# Altium schematic sheet BLOCK WIRELESS.SchDoc
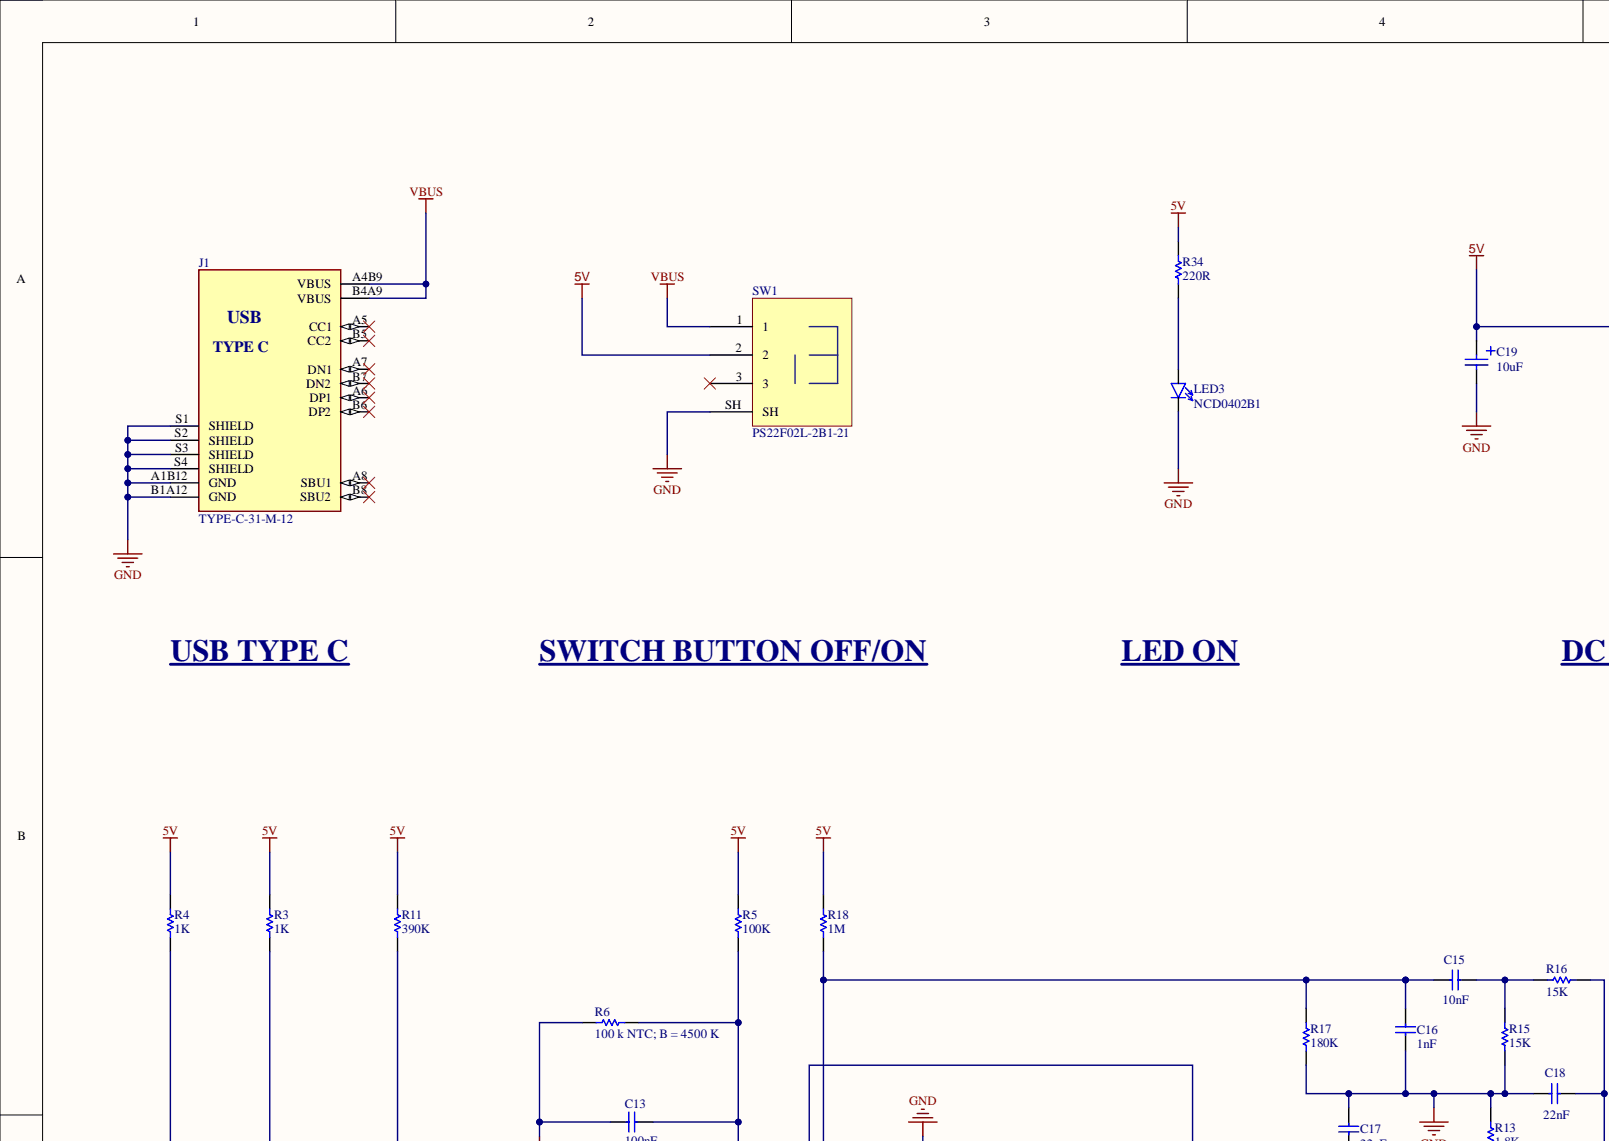
[diagram p0: BLOCK WIRELESS.SchDoc, top left]
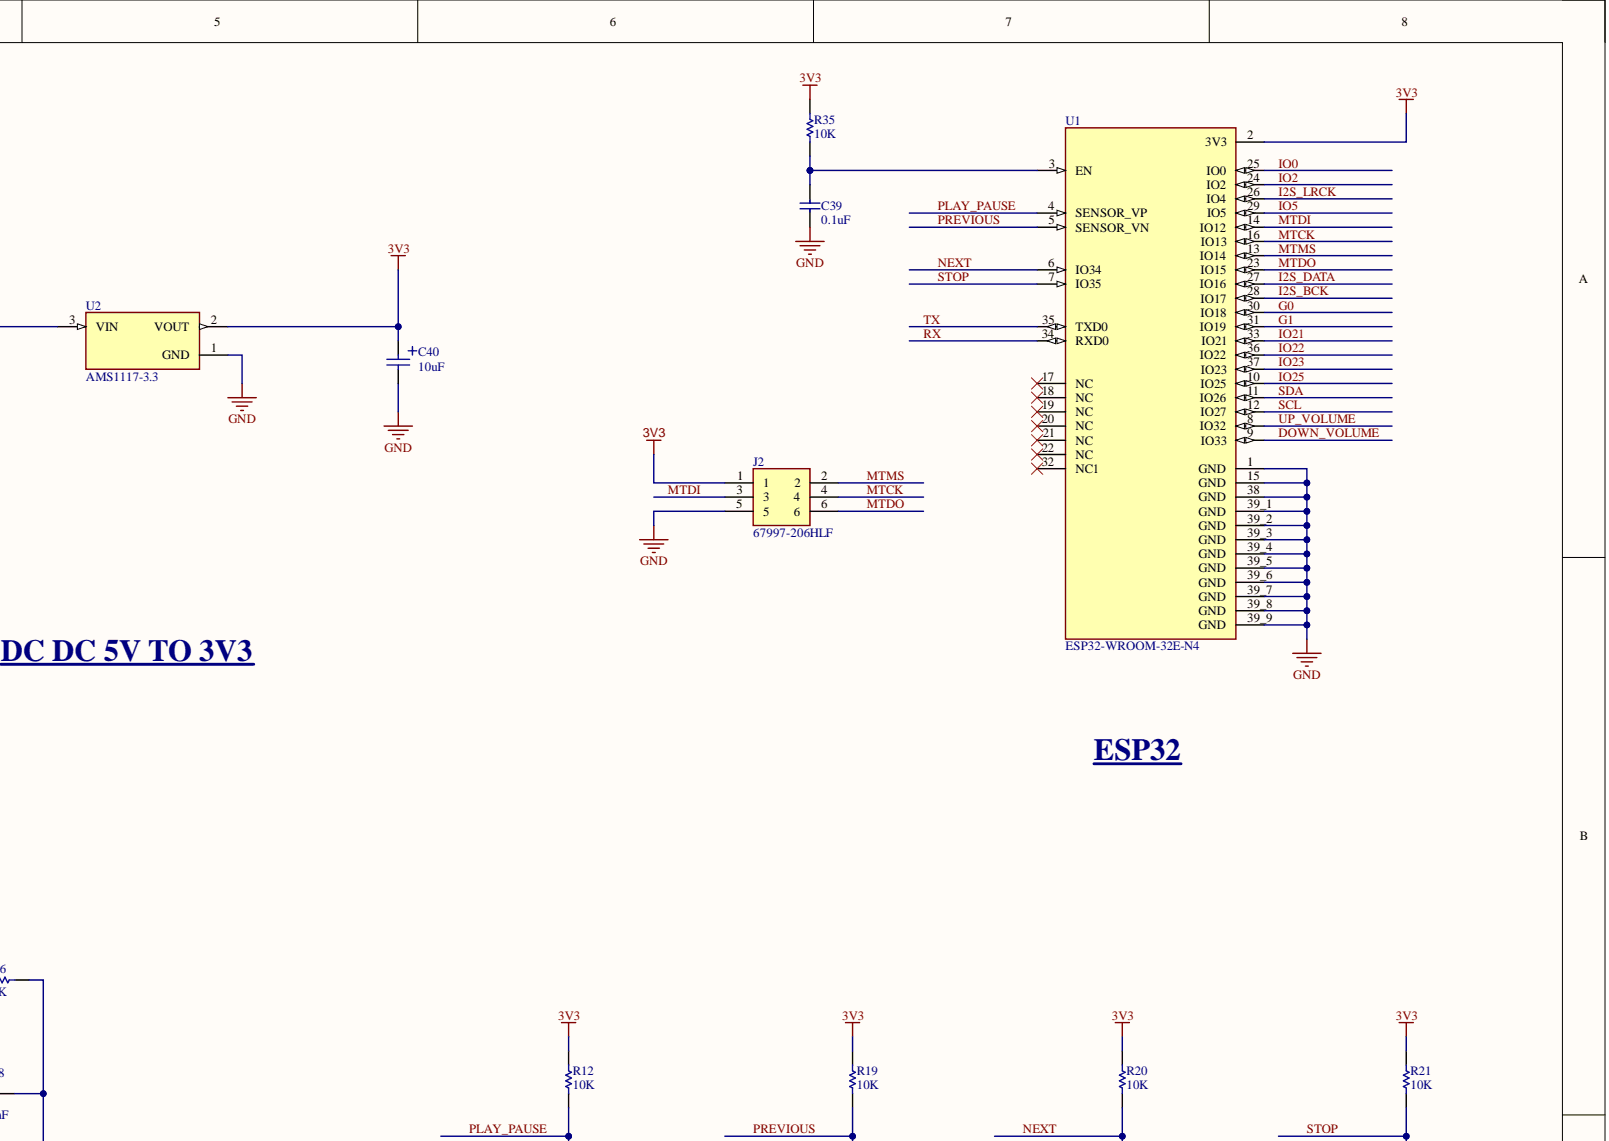
[diagram p1: BLOCK WIRELESS.SchDoc, top right]
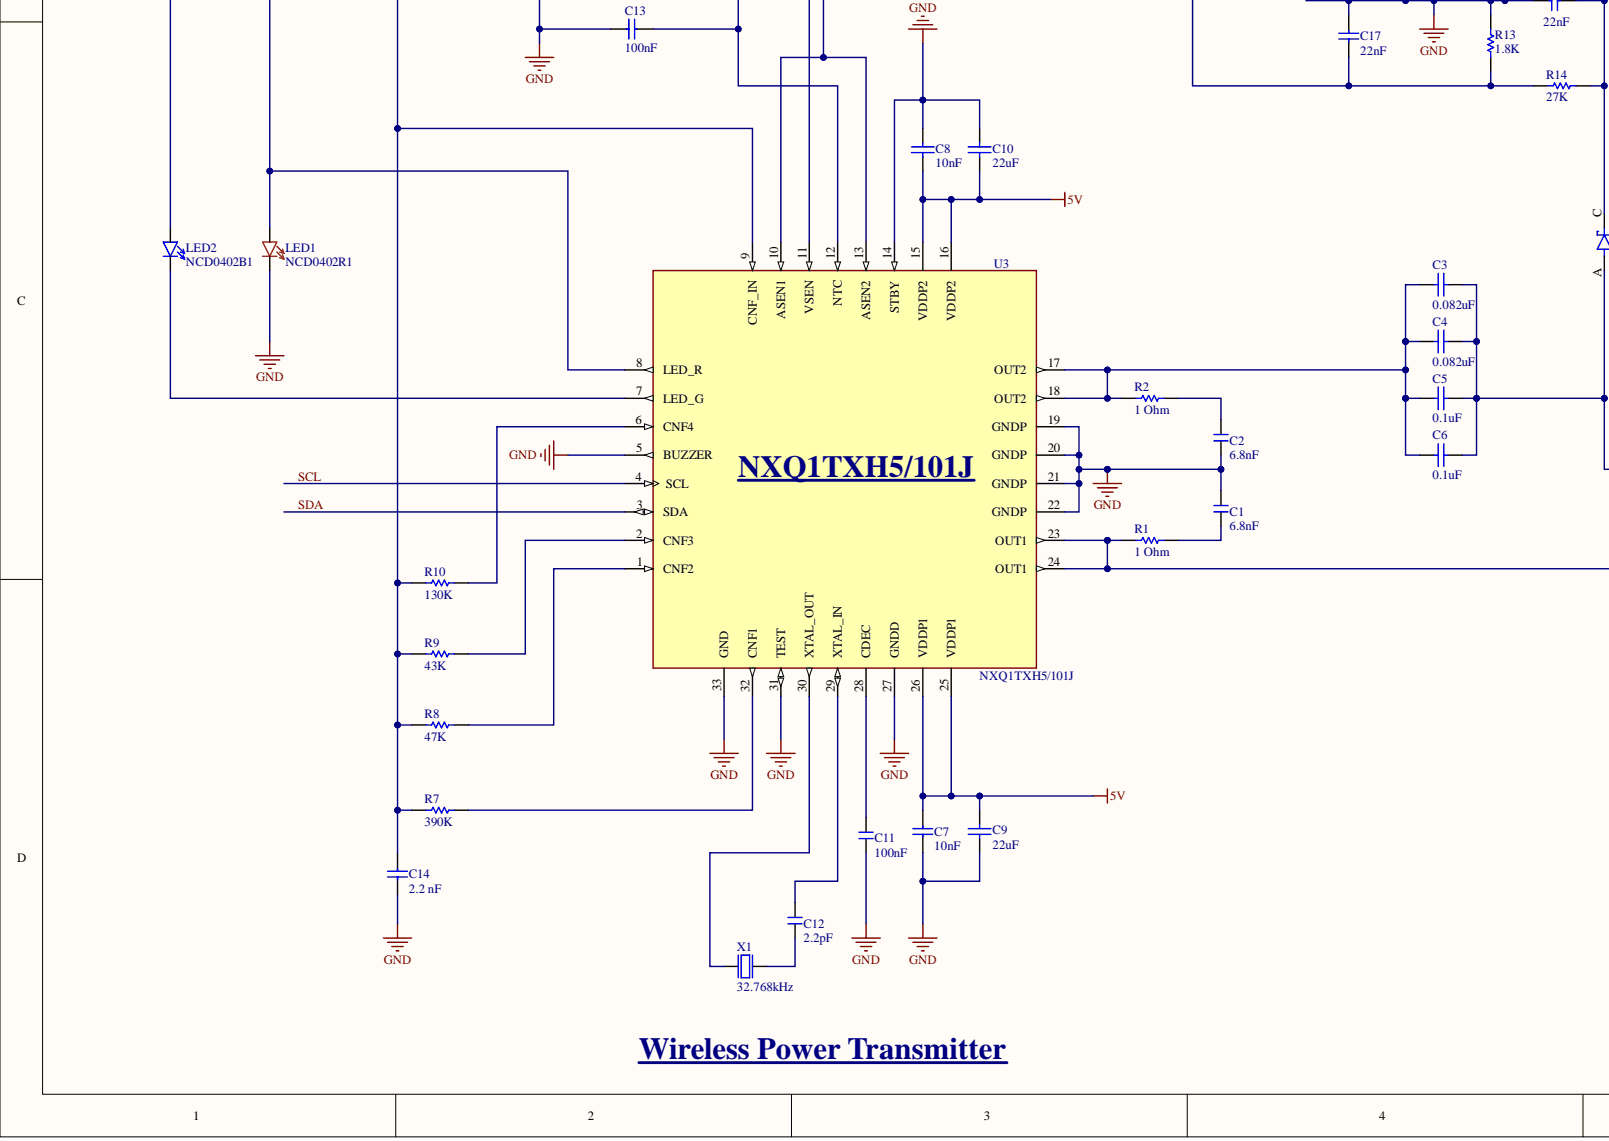
[diagram p2: BLOCK WIRELESS.SchDoc, bottom left]
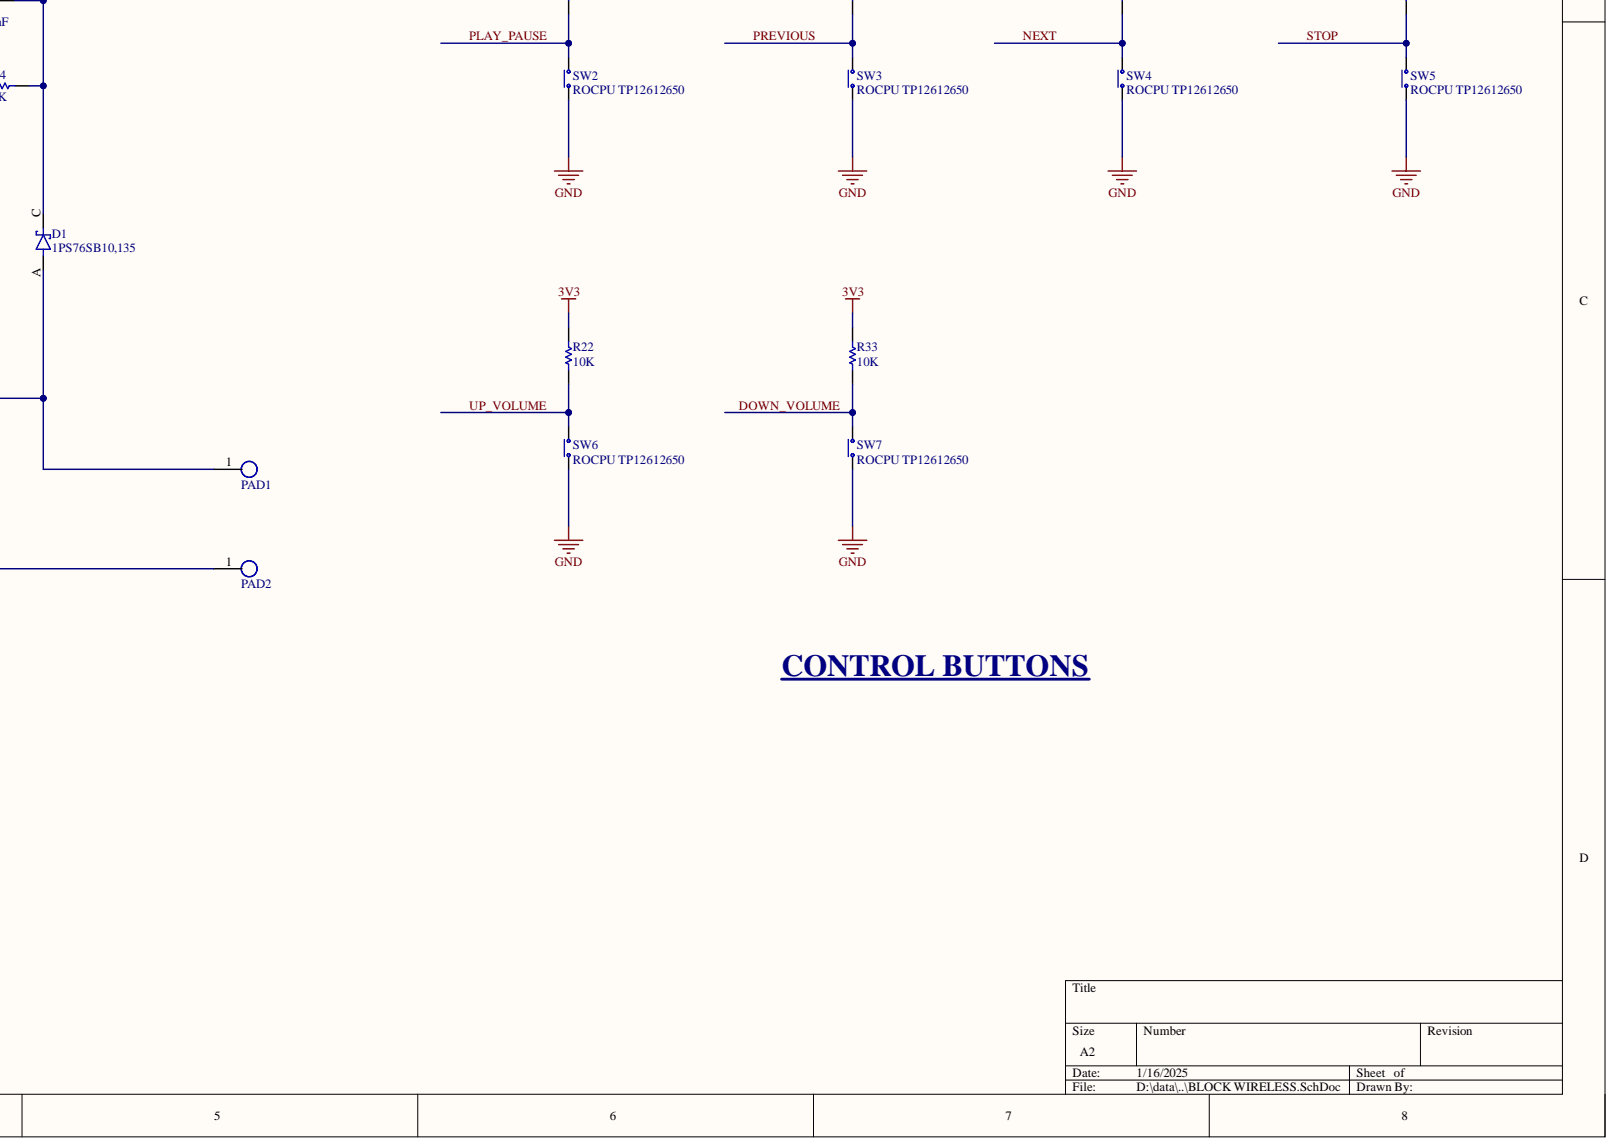
[diagram p3: BLOCK WIRELESS.SchDoc, bottom right]

## Parts
C1  6.8nF  [C0201]
C2  6.8nF  [C0201]
C3  0.082uF  [C0805]
C4  0.082uF  [C0805]
C5  0.1uF  [C0805]
C6  0.1uF  [C0805]
C7  10nF  [CAPC1005X04L]
C8  10nF  [C0603]
C9  22uF  [C0805]
C10  22uF  [C0805]
C11  100nF  [C0201]
C12  2.2pF  [C0201]
C13  100nF  [CAPC1005X04L]
C14  2.2 nF  [CAPC1005X04L]
C15  10nF  [CAPC1005X04L]
C16  1nF  [CAPC1005X04L]
C17  22nF  [CAPC1005X04L]
C18  22nF  [CAPC1005X04L]
C19  10uF  [C0805+]
C39  0.1uF  [CAPC1005X04L]
C40  10uF  [C0805+]
D1  1PS76SB10,135  [SOD323]
J1  TYPE-C-31-M-12  [HRO_TYPE-C-31-M-12]
J2  67997-206HLF  [67997-206HLF]
LED1  NCD0402R1  [LED0402]
LED2  NCD0402B1  [LED0402]
LED3  NCD0402B1  [LED0402]
PAD1  PAD  [test_point]
PAD2  PAD  [test_point]
R1  1 Ohm  [R0201]
R2  1 Ohm  [R0201]
R3  1K  [R0402]
R4  1K  [R0402]
R5  100K  [R0402]
R6  100 k NTC; B = 4500 K  [THERMISTOR]
R7  390K  [R0402]
R8  47K  [R0402]
R9  43K  [R0402]
R10  130K  [R0603]
R11  390K  [R0402]
R12  10K  [R0603]
R13  1.8K  [R0402]
R14  27K  [R0402]
R15  15K  [R0402]
R16  15K  [R0402]
R17  180K  [R0402]
R18  1M  [R0402]
R19  10K  [R0603]
R20  10K  [R0603]
R21  10K  [R0603]
R22  10K  [R0603]
R33  10K  [R0603]
R34  220R  [R0603]
R35  10K  [R0402]
SW1  PS22F02L-2B1-21  [switch_horizontal]
SW2  ROCPU TP12612650  [TP12612650]
SW3  ROCPU TP12612650  [TP12612650]
SW4  ROCPU TP12612650  [TP12612650]
SW5  ROCPU TP12612650  [TP12612650]
SW6  ROCPU TP12612650  [TP12612650]
SW7  ROCPU TP12612650  [TP12612650]
U1  ESP32-WROOM-32E-N4  [XCVR_ESP32-WROOM-32E_(16MB)]
U2  AMS1117-3.3  [SOT229P700X180-4N]
U3  NXQ1TXH5/101J  [QFN50P500X500X85-33N]
X1  32.768kHz  [FC-135 32.7680KA-A5]

## Nets
3V3: C40.1 J2.1 R12.2 R19.2 R20.2 R21.2 R22.2 R33.2 R35.2 U1.2(3V3) U2.2
5V: C7.2 C8.1 C9.2 C10.1 C19.1 R3.2 R4.2 R5.2 R11.2 R18.2 R34.2 SW1.2 U2.3 U3.15(VDDP2) U3.16(VDDP2) U3.25(VDDP1) U3.26(VDDP1)
GND: C1.2 C2.1 C7.1 C8.2 C9.1 C10.2 C11.1 C13.2 C14.1 C16.2 C17.1 C18.1 C19.2 C39.1 C40.2 J1.A1B12(GND) J1.B1A12(GND) J1.S1(SHIELD) J1.S2(SHIELD) J1.S3(SHIELD) J1.S4(SHIELD) J2.5 LED1.C LED3.C R6.1 R13.2 R15.1 R17.1 SW1.SH SW2.2 SW3.2 SW4.2 SW5.2 SW6.2 SW7.2 U1.1(GND) U1.15(GND) U1.38(GND) U1.39_1(GND) U1.39_2(GND) U1.39_3(GND) U1.39_4(GND) U1.39_5(GND) U1.39_6(GND) U1.39_7(GND) U1.39_8(GND) U1.39_9(GND) U2.1 U3.5(BUZZER) U3.14(STBY) U3.19(GNDP) U3.20(GNDP) U3.21(GNDP) U3.22(GNDP) U3.27(GNDD) U3.31(TEST) U3.33(GND)
VBUS: J1.A4B9(VBUS) J1.B4A9(VBUS) SW1.1
DOWN_VOLUME: R33.1 SW7.1 U1.9(IO33)
G0: U1.30(IO18)
G1: U1.31(IO19)
I2S_BCK: U1.28(IO17)
I2S_DATA: U1.27(IO16)
I2S_LRCK: U1.26(IO4)
IO0: U1.25(IO0)
IO2: U1.24(IO2)
IO5: U1.29(IO5)
IO21: U1.33(IO21)
IO22: U1.36(IO22)
IO23: U1.37(IO23)
IO25: U1.10(IO25)
MTCK: J2.4 U1.16(IO13)
MTDI: J2.3 U1.14(IO12)
MTDO: J2.6 U1.23(IO15)
MTMS: J2.2 U1.13(IO14)
NEXT: R20.1 SW4.1 U1.6(IO34)
PLAY_PAUSE: R12.1 SW2.1 U1.4(SENSOR_VP)
PREVIOUS: R19.1 SW3.1 U1.5(SENSOR_VN)
RX: U1.34(RXD0)
SCL: U1.12(IO27) U3.4(SCL)
SDA: U1.11(IO26) U3.3(SDA)
STOP: R21.1 SW5.1 U1.7(IO35)
TX: U1.35(TXD0)
UP_VOLUME: R22.1 SW6.1 U1.8(IO32)
NetC1_1: C1.1 R1.1
NetC2_2: C2.2 R2.2
NetC3_1: C3.1 C4.1 C5.1 C6.1 R2.1 U3.17(OUT2) U3.18(OUT2)
NetC3_2: C3.2 C4.2 C5.2 C6.2 D1.A PAD1.1
NetC11_2: C11.2 U3.28(CDEC)
NetC12_1: C12.1 X1.2
NetC12_2: C12.2 U3.29(XTAL_IN)
NetC13_1: C13.1 R5.1 R6.2 U3.12(NTC)
NetC14_2: C14.2 R7.1 R8.1 R9.1 R10.1 R11.1 U3.9(CNF_IN)
NetC15_1: C15.1 C16.1 R17.2 R18.1 U3.10(ASEN1) U3.13(ASEN2)
NetC15_2: C15.2 R15.2 R16.1
NetC17_2: C17.2 R13.1 R14.1 U3.11(VSEN)
NetC18_2: C18.2 D1.C R14.2 R16.2
NetC39_2: C39.2 R35.1 U1.3(EN)
NetLED1_A: LED1.A R3.1 U3.8(LED_R)
NetLED2_A: LED2.A R4.1
NetLED2_C: LED2.C U3.7(LED_G)
NetLED3_A: LED3.A R34.1
NetPAD2_1: PAD2.1 R1.2 U3.23(OUT1) U3.24(OUT1)
NetR7_2: R7.2 U3.32(CNF1)
NetR8_2: R8.2 U3.1(CNF2)
NetR9_2: R9.2 U3.2(CNF3)
NetR10_2: R10.2 U3.6(CNF4)
NetU3_30: U3.30(XTAL_OUT) X1.1

Not connected: J1 A5(CC1) A6(DP1) A7(DN1) A8(SBU1) B5(CC2) B6(DP2) B7(DN2) B8(SBU2); SW1 3; U1 17(NC) 18(NC) 19(NC) 20(NC) 21(NC) 22(NC) 32(NC1)

## Library data of the parts
C1 C2 C11 C12 = C0201: CAPACITOR 0201 FOOTPRINT; Manufacturer: Murata Electronics North America; Part Number: GCM033R71A103KA03D; @ qty: 0; Minimum Quantity: 1; Temperature Coefficient: X7R; Tolerance: ±10%; Unit Price (USD): 0.1; Voltage: 10V; Applications: Automotive; Component Kind: Standard; Datasheets: http://www.murata.com/~/media/webrenewal/support/library/catalog/products/capacitor/mlcc/c03e.ashx; Digi-Key Part Number: 490-6040-1-ND; Factory Stock: 0; Failure Rate: Surface Mount, MLCC; Features: -; Height - Seated (Max): 0.013" (0.33mm); Image: //media.digikey.com/Renders/Murata%20Renders/0201-0603.jpg; Lead Spacing: -; Library Name: my_library.SchLib; Mounting Type: 0201 (0603 Metric); Operating Temperature: -55°C ~ 125°C; Package / Case: 0.024" L x 0.012" W (0.60mm x 0.30mm); Packaging: Cut Tape (CT); Part Status: Active; Quantity Available: 388635; Ratings: AEC-Q200; Series: GCM; Size / Dimension: -; Thickness (Max): -
C3-C6 C9 C10 = C0805: Capacitor; Component Kind: Standard; Library Name: my_library.SchLib
C7 C13-C18 C39 = C0402: Capacitor, X5R, ±5%, 6.3V; Manufacturer: N.A.; Part Number: C0402-1µ0; Part number IPS: 00000007786, 00000003457; Artikkelnr Noca: 20039235; Pad Count: 2; Value: 1.0µF; Component Class: Capacitors; Component Kind: Standard; ComponentLink1Description: Datasheet; ComponentLink1URL: N.A.; ComponentLink2Description: Supplier link; ComponentLink2URL: N.A.; Footprint Doc: 0402; HelpURL: N.A.; LatestRevisionDate: 2016-08-22; Library Name: my_library.SchLib; Manufacturer Part Number: N.A.; Note: 6.3V; PartId: 100200190; Published: 2009-05-08; Supplier 1: Digi-Key; Supplier 2: N.A.; Supplier Part Number 1: 1276-1444-1-ND; Supplier Part Number 2: N.A.
C8 = C0603: capacitor; 10 nF; 50 V; NP0; Component Kind: Standard; Library Name: my_library.SchLib
C19 C40 = tantalum_capacitor_0805: Component Kind: Standard; Library Name: my_library.SchLib
J1 = TYPE-C-31-M-12: USB Connectors 24 Receptacle 1 8.94*7.3mm RoHS; MF: HRO Electronics Co., Ltd.; Availability: Unavailable; Component Kind: Standard; Library Name: my_library.SchLib; MP: TYPE-C-31-M-12; Package: Package; Price: None; SnapEDA_Link: https://www.snapeda.com/parts/TYPE-C-31-M-12/HRO%20Electronics%20Co.,%20Ltd./view-part/3228496/?ref=snap
J2 = 67997-206HLF: Connector Header Through Hole 6 position 0.100 _2.54mm_; MF: Amphenol ICC; Component Kind: Standard; Digi-Key_Part_Number: 609-3234-ND; Digi-Key_Purchase_URL: https://www.digikey.com/product-detail/en/amphenol-icc-fci/67997-206HLF/609-3234-ND/1878491?utm_source=snapeda&utm_medium=aggregator&utm_campaign=symbol; MP: 67997-206HLF; Package: None; SnapEDA_Link: https://www.snapeda.com/parts/67997-206HLF/Amphenol%20FCI/view-part/103429/?ref=snap; Library Name: motherboard_musicsuit.SchLib
LED1 = RED_led: Component Kind: Standard; Library Name: my_library.SchLib
LED2 LED3 = blue_led: Component Kind: Standard; Library Name: my_library.SchLib
R1 R2 = R0201: Resistor; Component Kind: Standard; Library Name: my_library.SchLib
R3-R5 R7-R9 R11 R13-R18 R35 = R0402: Resistor; Component Kind: Standard; Library Name: my_library.SchLib
R6 = Thermistor: thermistor; 100 k NTC; B = 4500 K; Component Kind: Standard; Library Name: my_library.SchLib
R10 R12 R19-R22 R33 = R0603: Resistor; Component Kind: Standard; Library Name: my_library.SchLib
R34 = R0603: resistor; 1 Ohm; 5 %; Component Kind: Standard; Library Name: my_library.SchLib
SW1 = Switch_horizontal: Component Kind: Standard; Description: PS22F02L-2B1-21; Library Name: my_library.SchLib
SW2-SW7 = TP12612650: ROCPU Switches   50mA 100MΩ 50000次 12V 160gf@±50gf Plug-in SPST Plugin Tactile Switches ROHS; Manufacturer: Dailywell; Manufacturer Part Number: TS604VM1-025BR-R; Part Number: Switch-PB-6.2x6.3mm-SMD; Part number IPS: 00000007812; Artikkelnr Noca: 50019106; Note: N.A.; Pad Count: 4; Component Class: Switches; Component Kind: Standard; ComponentLink1Description: Datasheet; ComponentLink1URL: N.A.; ComponentLink2Description: Supplier link; ComponentLink2URL: N.A.; Footprint Doc: Switch-PB-6.2x6.3mm-SMD; HelpURL: \\hizz\pro\app9717\Datasheet\switches\Dailywell\TS604VM1-025BR-R.pdf; LatestRevisionDate: 2016-04-21; Library Name: my_library.SchLib; PartId: 101500001; Published: 2010-02-01; Supplier 1: Cabcon; Supplier 2: Mouser; Supplier Part Number 1: TS604VM1-025BR-R; Supplier Part Number 2: 688-SKHUALE010; Value: ROCPU TP12612650
U1 = ESP32-WROOM-32E-N4: Bluetooth, WiFi 802.11b/g/n, Bluetooth v4.2 +EDR, Class 1, 2 and 3 Transceiver Module 2.4GHz ~ 2.5GHz Integrated, Trace Surface Mount; MF: Espressif Systems; MP: ESP32-WROOM-32E (16MB); Availability: Not in stock; Check_prices: https://www.snapeda.com/parts/ESP32-WROOM-32E%20(16MB)/Espressif+Systems/view-part/?ref=eda; Component Kind: Standard; Library Name: my_library.SchLib; Package: None; Price: None; SnapEDA_Link: https://www.snapeda.com/parts/ESP32-WROOM-32E%20(16MB)/Espressif+Systems/view-part/?ref=snap
U2 = AMS1117-3.3: Sot223/Pkg 1-Amp 3.3-Volt Low Drop Out Voltage Regulatator; MF: Advanced Monolithic Systems; Availability: Not in stock; Check_prices: https://www.snapeda.com/parts/AMS1117-3.3/Advanced+Monolithic+Systems/view-part/?ref=eda; Component Kind: Standard; Library Name: my_library.SchLib; MP: AMS1117-3.3; Package: SOT-223 Seeed Technology; Price: None; SnapEDA_Link: https://www.snapeda.com/parts/AMS1117-3.3/Advanced+Monolithic+Systems/view-part/?ref=snap
U3 = NXQ1TXH5/101J: RF Transmitter 110kHz ~ 205kHz Antenna 32-VFQFN Exposed Pad; MF: NXP USA; Component Kind: Standard; Digi-Key_Part_Number: 568-12937-1-ND; Digi-Key_Purchase_URL: https://www.digikey.sg/product-detail/en/nxp-usa-inc/NXQ1TXH5-101J/568-12937-1-ND/5973986?utm_source=snapeda&utm_medium=aggregator&utm_campaign=symbol; Library Name: my_library.SchLib; MP: NXQ1TXH5/101J; Package: VFQFN-32 NXP Semiconductors; SnapEDA_Link: https://www.snapeda.com/parts/NXQ1TXH5/101J/NXP%20USA%20Inc./view-part/558219/?ref=snap
X1 = FC-135 32.7680KA-A5: XTAL SMD 1610, 32.768kHz, 9pF, ±20ppm; Manufacturer: Seiko Instruments; Manufacturer Part Number: SC16S-9.0PF20PPM; Part Number: XTAL_SMD1610_32.768kHz_9pF_Seiko; Part number IPS: 00000001802; Artikkelnr Noca: N.A.; Note: XTAL SMD 32.768kHz; Pad Count: 2; Component Class: Oscillators; Component Kind: Standard; ComponentLink1Description: Datasheet; ComponentLink1URL: N.A.; ComponentLink2Description: Supplier link; ComponentLink2URL: N.A.; Footprint Doc: XTAL_1610; HelpURL: http://www.sii.co.jp/en/quartz/files/2014/07/SC-16S_Leaflet_r.pdf; LatestRevisionDate: 2015-10-15; Library Name: my_library.SchLib; PartId: 101600010; Published: 2015-10-15; Supplier 1: N.A.; Supplier 2: N.A.; Supplier Part Number 1: N.A.; Supplier Part Number 2: N.A.; Value: 32.768kHz
D1 = 1PS76SB10,135: Component Kind: Standard; Library Name: my_library.SchLib
PAD1 PAD2 = PAD: Component Kind: Standard; Library Name: my_library.SchLib
